# Altium schematic sheet Sheet1.SchDoc
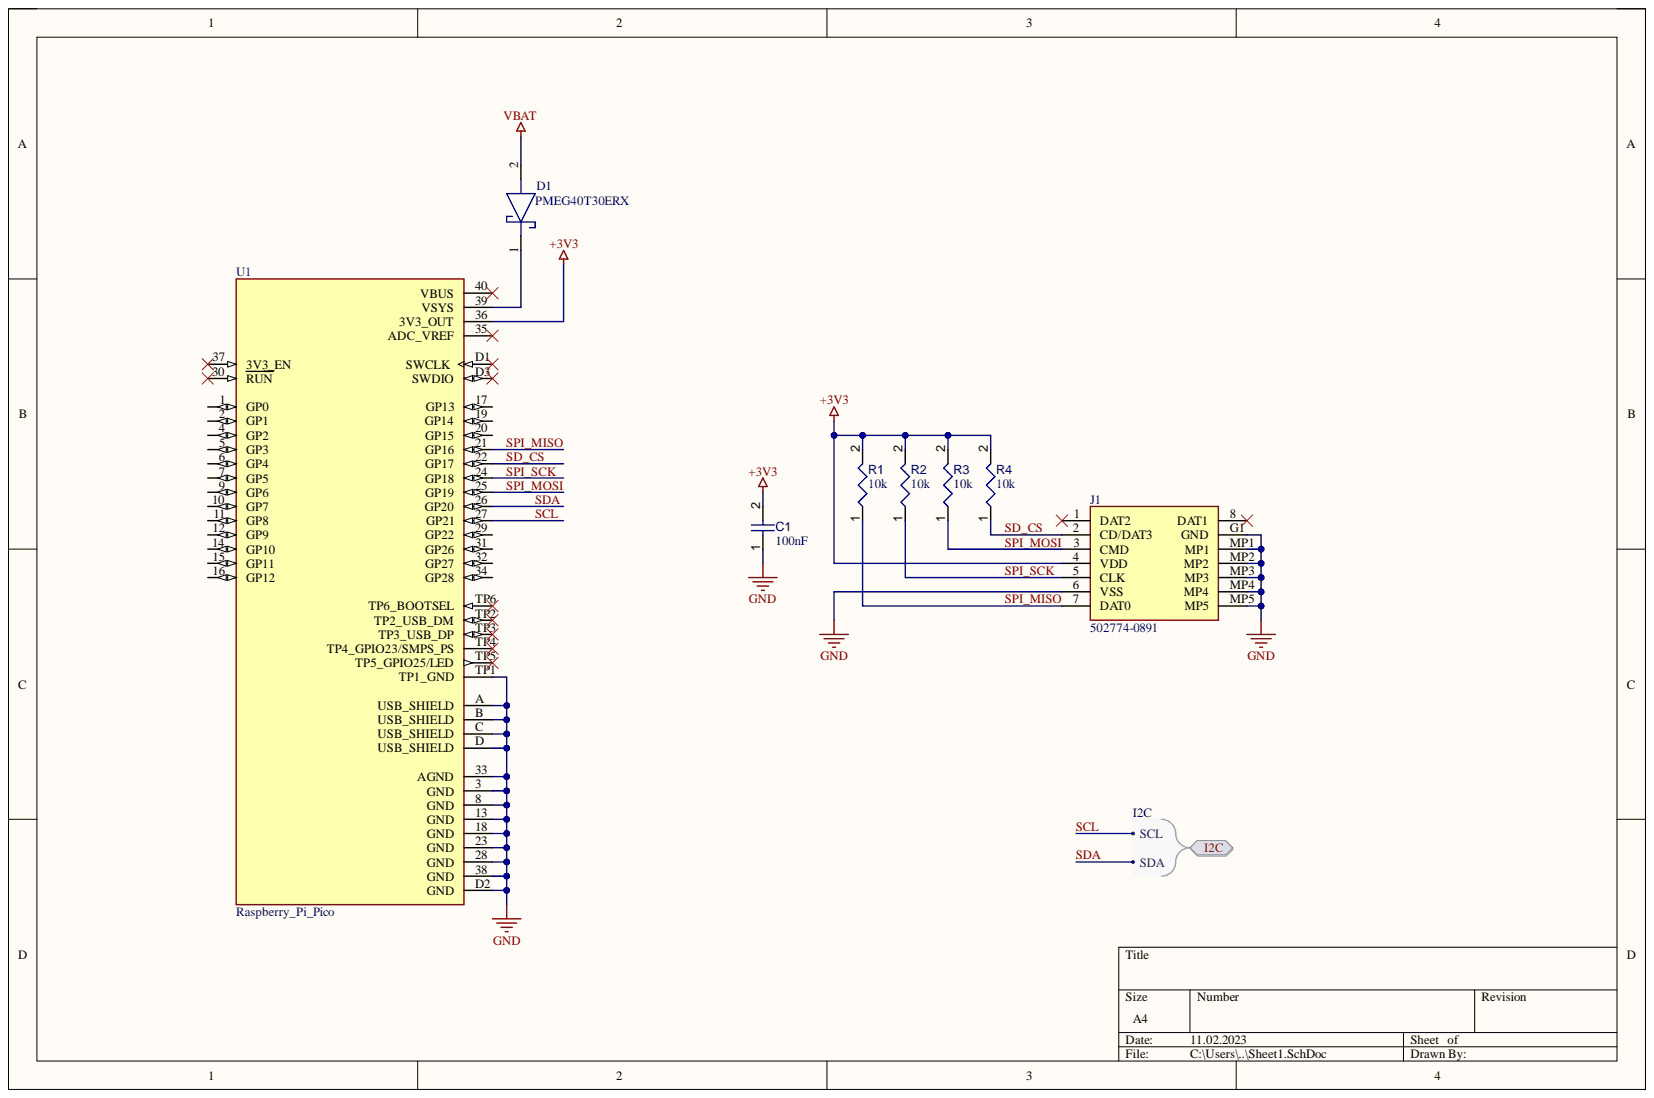
[diagram p0: Sheet1.SchDoc]

## Parts
C1  value 100nF  [CAPC1608X90X40NL10T20]  (hidden comment: Capacitor 100 nF +/-10% 50 V 0603)
D1  PMEG40T30ERX  [SODFL3517X110N]
J1  502774-0891  [5027740891]
R1  value 10k  [RESC1608X55X25ML10T15]  (hidden comment: Resistor 10k +/-1% 0603 100 mW)
R2  value 10k  [RESC1608X55X25ML10T15]  (hidden comment: Resistor 10k +/-1% 0603 100 mW)
R3  value 10k  [RESC1608X55X25ML10T15]  (hidden comment: Resistor 10k +/-1% 0603 100 mW)
R4  value 10k  [RESC1608X55X25ML10T15]  (hidden comment: Resistor 10k +/-1% 0603 100 mW)
U1  Raspberry_Pi_Pico  [MODULE_SC0915]

## Ports
I2C, I2C.SCL, I2C.SDA

## Nets
+3V3: C1.2 J1.4(VDD) R1.2 R2.2 R3.2 R4.2 U1.36(3V3_OUT)
GND: C1.1 J1.6(VSS) J1.G1(GND) J1.MP1 J1.MP2 J1.MP3 J1.MP4 J1.MP5 U1.3(GND) U1.8(GND) U1.13(GND) U1.18(GND) U1.23(GND) U1.28(GND) U1.33(AGND) U1.38(GND) U1.A(USB_SHIELD) U1.B(USB_SHIELD) U1.C(USB_SHIELD) U1.D(USB_SHIELD) U1.D2(GND) U1.TP1(TP1_GND)
VBAT: D1.2
SCL: U1.27(GP21) ; port I2C
SD_CS: J1.2(CD/DAT3) R4.1 U1.22(GP17)
SDA: U1.26(GP20) ; port I2C
SPI_MISO: J1.7(DAT0) R1.1 U1.21(GP16)
SPI_MOSI: J1.3(CMD) R3.1 U1.25(GP19)
SPI_SCK: J1.5(CLK) R2.1 U1.24(GP18)
NetD1_1: D1.1 U1.39(VSYS)

Not connected: J1 1(DAT2) 8(DAT1); U1 1(GP0) 2(GP1) 4(GP2) 5(GP3) 6(GP4) 7(GP5) 9(GP6) 10(GP7) 11(GP8) 12(GP9) 14(GP10) 15(GP11) 16(GP12) 17(GP13) 19(GP14) 20(GP15) 29(GP22) 30(RUN) 31(GP26) 32(GP27) 34(GP28) 35(ADC_VREF) 37(3V3_EN) 40(VBUS) D1(SWCLK) D3(SWDIO) TP2(TP2_USB_DM) TP3(TP3_USB_DP) TP4(TP4_GPIO23/SMPS_PS) TP5(TP5_GPIO25/LED) TP6(TP6_BOOTSEL)

## Library data of the parts
C1 = CMP-001-00017-6: Chip Capacitor, 100 nF, +/- 10%, 50 V, 0603 (1608 Metric); Capacitor Type: Ceramic; Voltage Rating: 50V; Case/Package: 0603; Component Kind: Standard; Library Name: PWr in Space team; Max Operating Temperature: 125°C; Min Operating Temperature: -55°C; Mounting Technology: SM; Pins: 2; RoHS Compliant: True; Tolerance: 10%
D1 = PMEG60T30ELRX: Schottky Diode; Manufacturer_Name: Nexperia; Arrow Part Number: PMEG60T30ELRX; Arrow Price/Stock: https://www.arrow.com/en/products/pmeg60t30elrx/nexperia?region=nac; Component Kind: Standard; Datasheet Link: https://assets.nexperia.com/documents/data-sheet/PMEG60T30ELR.pdf; Height: 1.1mm; Manufacturer_Part_Number: PMEG60T30ELRX; Mouser Part Number: 771-PMEG60T30ELRX; Mouser Price/Stock: https://www.mouser.co.uk/ProductDetail/Nexperia/PMEG60T30ELRX?qs=MLItCLRbWswWnbT%2FWpJcxg%3D%3D
J1 = 502774-0891: Connector; Manufacturer_Name: Molex; Component Kind: Standard; Datasheet Link: https://www.molex.com/content/dam/molex/molex-dot-com/products/automated/en-us/salesdrawingpdf/502/502774/5027740891_sd.pdf; Height: 1.95mm; Manufacturer_Part_Number: 502774-0891; Mouser Part Number: 538-502774-0891; Mouser Price/Stock: https://www.mouser.co.uk/ProductDetail/Molex/502774-0891?qs=HaAz2OYkmGggsnJ7oFWqbQ%3D%3D
R1-R4 = CMP-009-00017-5: Chip Resistor, 10 KOhm, +/- 1%, 01 W, -55 to 155 degC, 0603 (1608 Metric); Power: 100mW; Voltage Rating: 50V; Case/Package: 0603; Component Kind: Standard; Library Name: PWr in Space team; Max Operating Temperature: 155°C; Min Operating Temperature: -55°C; Mounting Technology: SM; Pins: 2; RoHS Compliant: True; Tolerance: 1%
U1 = CMP-090-000000-1: RP2040 Raspberry Pi Pico series ARMÂ® CortexÂ®-M0+ MCU 32-Bit Embedded Evaluation Board; MP: SC0915; Availability: In Stock; Check_prices: https://www.snapeda.com/parts/SC0915/Raspberry+Pi/view-part/?ref=eda; Component Kind: Standard; Component Type: Standard; Design Item ID: SC0915; Library Name: PWr in Space team; MF: Raspberry Pi; Package: None; Pin Count: 53; Price: None; Purchase-URL: https://pricing.snapeda.com/search/part/SC0915/?ref=eda; SnapEDA_Link: https://www.snapeda.com/parts/SC0915/Raspberry+Pi/view-part/?ref=snap; Source: SC0915.SchLib
